# Altium schematic sheet OUTPUT.SchDoc(OUTPUT)
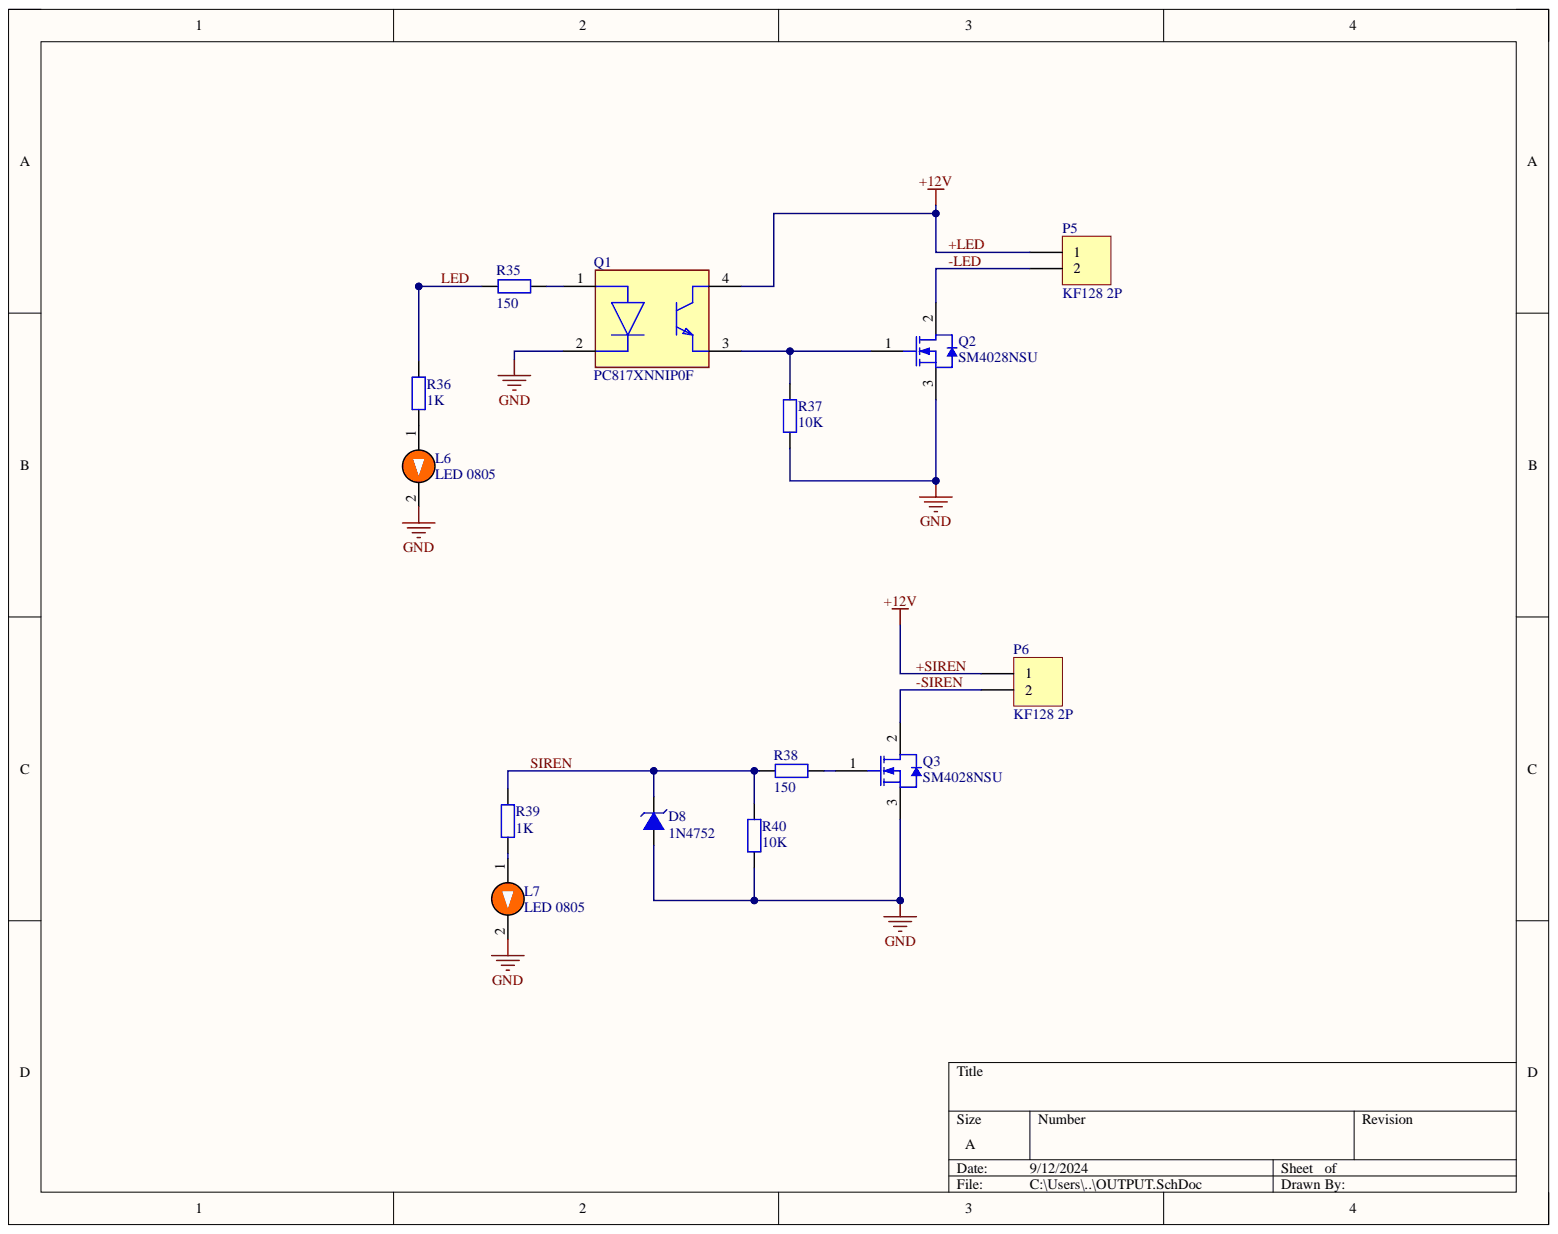
[diagram p0: OUTPUT.SchDoc]

## Parts
D8  1N4752  [DIODE ZENNER SMD SOD80]
L6  LED 0805  [LED-0805-Orange]
L7  LED 0805  [LED-0805-Orange]
P5  KF128 2P  [KF128_2P]
P6  KF128 2P  [KF128_2P]
Q1  PC817XNNIP0F  [FP-PC817XNNIP0F-MFG]
Q2  SM4028NSU  [INF-PG-TO252-3-313_L]
Q3  SM4028NSU  [INF-PG-TO252-3-313_L]
R35  150  [tro smd 0805]
R36  1K  [tro smd 0805]
R37  10K  [tro smd 0805]
R38  150  [tro smd 0805]
R39  1K  [tro smd 0805]
R40  10K  [tro smd 0805]

## Nets
GND: D8.1 L6.2 L7.2 Q1.2 Q2.3 Q3.3 R37.2 R40.2
+LED: P5.1 P6.1 Q1.4
-LED: P5.2 Q2.2
-SIREN: P6.2 Q3.2
LED: R35.2 R36.2
SIREN: D8.2 R38.2 R39.2 R40.1
NetL6_1: L6.1 R36.1
NetL7_1: L7.1 R39.1
NetQ1_1: Q1.1 R35.1
NetQ1_3: Q1.3 Q2.1 R37.1
NetQ3_1: Q3.1 R38.1

## Library data of the parts
D8 = Diode Zener 15V: Dán; Don_Vi: Con; Ma_Hang: MH002883; Ten_Linh_Kien: Diode Zener 1/2W 15V SMD1206 ZMM15; Chung_Loai: Dán; Component Kind: Standard; ComponentLink1Description: New link; ComponentLink1URL: https://www.thegioiic.com/zmm24-diode-zener-24v-500mw-smd; Library Name: Approxi.SCHLIB
L6 L7 = LED 0805 Cam: Dán; Don_Vi: Con; Ma_Hang: MH002328; Ten_Linh_Kien: LED 0805 Màu Cam ( Orange); Chung_Loai: Dán; Component Kind: Standard; ComponentLink1Description: New link; ComponentLink1URL: https://www.thegioiic.com/led-cam-0805-dan-smd-trong-suot-harvatek; ComponentLink2Description: New link; ComponentLink2URL: 340; Library Name: Approxi.SCHLIB
P5 P6 = KF128 2P: Header, 2-Pin, pitch 5.08mm; MPN: KF128-2P; Solder: WAVE; Component Kind: Standard; Library Name: Integrated_Library_gateway_tbl.IntLib
Q1 = CMP-65434-000005-1: OPTOISOLATOR 5KV TRANS 4SMD; Collector Emitter Saturation Voltage: 200mV; Collector Emitter Voltage (VCEO): 80V; Fall Time: 18000ns; Forward Current: 50mA; Forward Voltage: 1.4V; Input Type: DC; Max Power Dissipation: 200mW; Number of Channels: 1; Output Current per Channel: 30mA; Reverse Breakdown Voltage: 6V; Case/Package: SMD/SMT; Collector-emitter Voltage-Max: 200mV; Component Kind: Standard; ComponentLink1Description: New link; ComponentLink1URL: https://www.thegioiic.com/pc817x2nip0f-optoisolator-transistor-output-5000vrms-1-channel-4-smd; Contact Plating: Copper; Input Current: 50mA; Isolation Voltage: 5kV; Isolation Voltage-Max: 5kV; Lead Free: Lead Free; Max Collector Current: 50mA; Max Input Current: 50mA; Max Operating Temperature: 100°C; Max Output Voltage: 80V; Min Operating Temperature: -30°C; Mount: Surface Mount; Number of Elements: 1; Number of Pins: 4; Output Voltage: 80V; Package Quantity: 2000; Packaging: Tape and Reel; Power Dissipation: 200mW; Radiation Hardening: No; Rise Time: 18000ns; RoHS Compliant: Yes; Library Name: Approxi.SCHLIB
Q2 Q3 = CMP-1164-00349-1: N-Channel CoolMOS E6 Power-Transistor, 600 V VDS, 7.3 A ID, -55 to 150 degC, PG-TO252-3-313, Reel, Green; Manufacturer: Infineon; PartNumber: IPD60R600C6; Green: TRUE; ID (max): 7.3Â A; IDpuls (max): 19Â A; Polarity: N; Ptot (max): 63Â W; QG: 20.5Â nC; RDS (on) (max): 600Â mOhm; Rth: 2Â K/W; RthJA (max): 62Â K/W; VDS (max): 600Â V; VGS(th) (max): 3.5Â V; VGS(th) (min): 2.5Â V; Component Kind: Standard; ComponentLink1Description: Manufacturer URL; ComponentLink1URL: http://www.infineon.com/; ComponentLink2Description: Datasheet; ComponentLink2URL: http://www.infineon.com/dgdl/IPD60R600C6_2_0.pdf?folderId=db3a30431ff98815012019af55de3f2c&fileId=db3a30432239cccd0122a83152b97d6f; ComponentLink3Description: Package Specification; ComponentLink3URL: http://www.infineon.com/dgdl/PG-TO252-3-313-Package_Overview.pdf?folderId=db3a30432b57a660012b81890ade72d2&fileId=db3a30432b57a660012b8193af2872eb; DatasheetVersion: Rev. 2.0; Mounting Technology: Surface Mount; PackageDescription: 3-Pin Plastic (Green) Transistor Single Outline Package, 6.5 x 6.12 mm Body, 2.28 mm Pitch; PackageReference: PG-TO252-3-313; PackageVersion: Oct-10; Packing: Reel; RthJC (max): 2Â K/W; Technology: CoolMOS C6; Library Name: Approxi.SCHLIB
R35-R40 = Tro 0603 5% 10k: Dán; Don_Vi: Con; Ma_Hang: MH006316; So_Chan: 2; Ten_Linh_Kien: Trở 0603 5% 10k; Chung_Loai: Dán; Component Kind: Standard; ComponentLink1Description: New link; ComponentLink1URL: https://www.thegioiic.com/dien-tro-1-kohm-0603-1; ComponentLink2Description: New link; ComponentLink2URL: 2300; Library Name: Approxi.SCHLIB
